# Altium schematic sheet Controller.SchDoc(Controller)
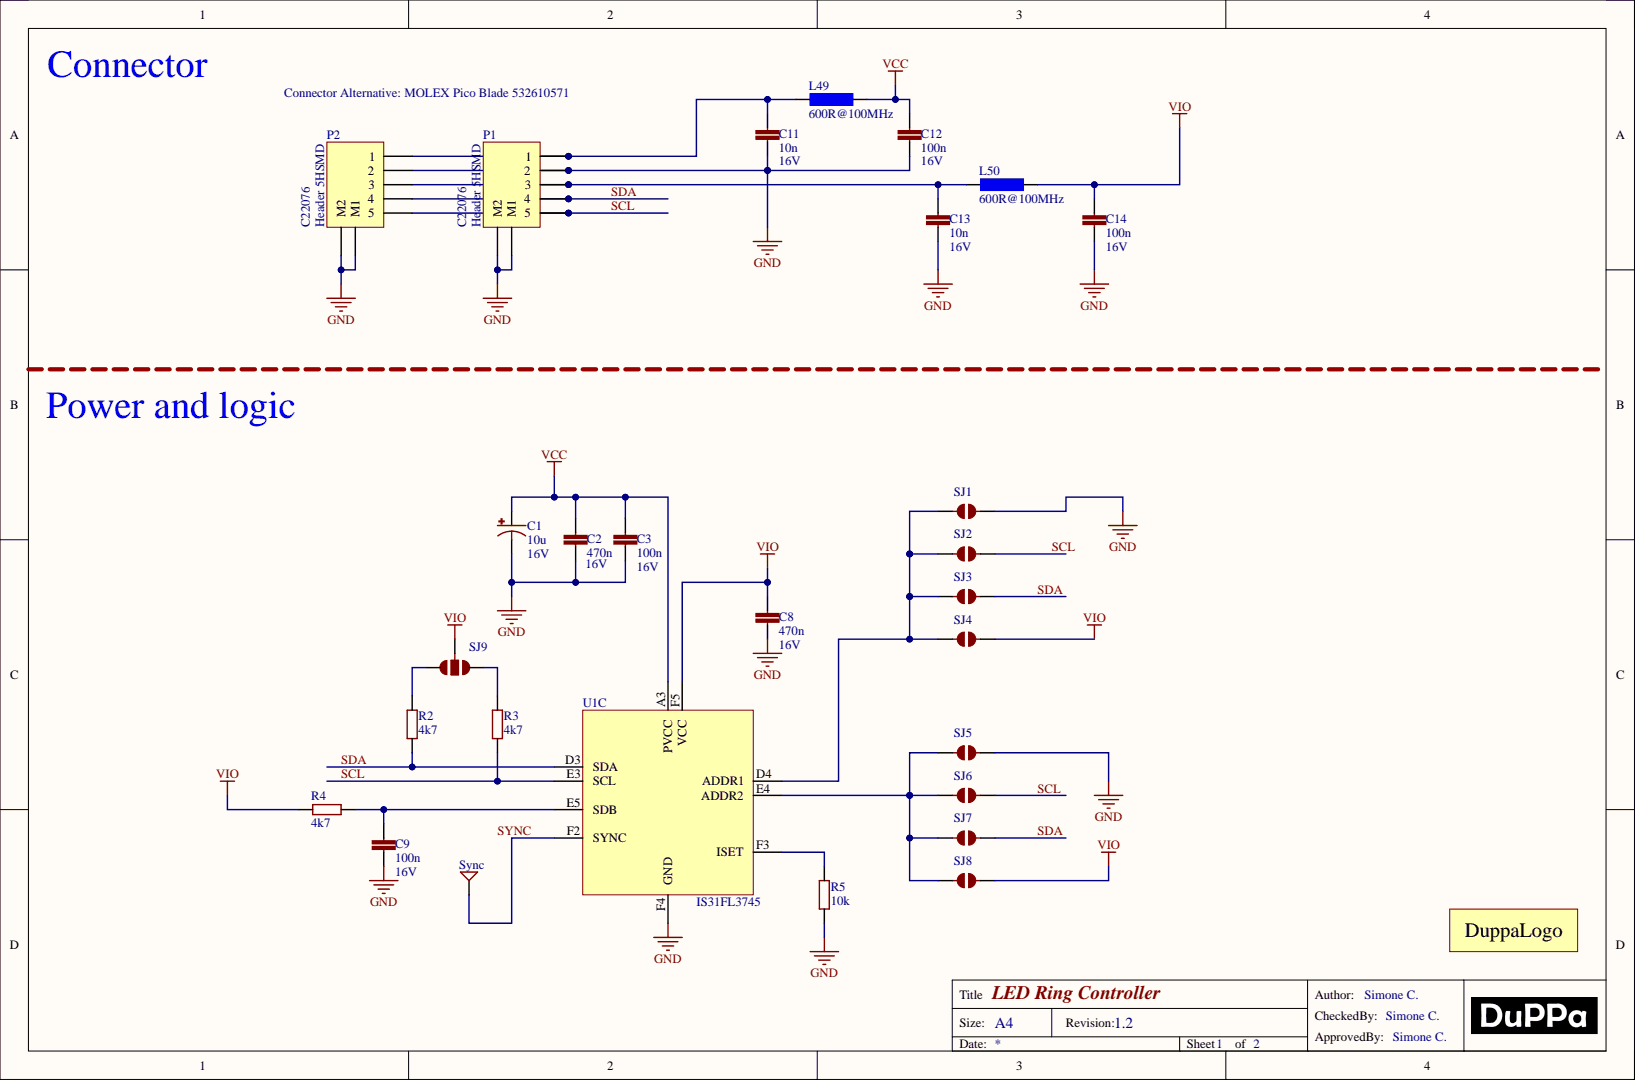
[diagram p0: Controller.SchDoc]

## Parts
C1  value 10u  Voltage: 16V  [SMC_A]  (hidden comment: Tantalum Case-A)
C2  value 470n  Voltage: 16V  [C0402]  (hidden comment: C-EUC0402)
C3  value 100n  Voltage: 16V  [C0402]  (hidden comment: C-EUC0402)
C8  value 470n  Voltage: 16V  [C0402]  (hidden comment: C-EUC0402)
C9  value 100n  Voltage: 16V  [C0402]  (hidden comment: C-EUC0402)
C11  value 10n  Voltage: 16V  [C0402]  (hidden comment: C-EUC0402)
C12  value 100n  Voltage: 16V  [C0402]  (hidden comment: C-EUC0402)
C13  value 10n  Voltage: 16V  [C0402]  (hidden comment: C-EUC0402)
C14  value 100n  Voltage: 16V  [C0402]  (hidden comment: C-EUC0402)
L49  600R@100MHz  [L0805]
L50  600R@100MHz  [L0402]
logo1  DuppaLogo  [DUPPA_TOP_300X76]  (no BOM)
P1  Header 5HSMD  PartNumber: C22076  [MOLX-52361-0571-5_V]
P2  Header 5HSMD  PartNumber: C22076  [MOLX-52361-0571-5_V]
R2  value 4k7  [R0402]  (hidden comment: R-EU_R0402)
R3  value 4k7  [R0402]  (hidden comment: R-EU_R0402)
R4  value 4k7  [R0402]  (hidden comment: R-EU_R0402)
R5  value 10k  [R0402]  (hidden comment: R-EU_R0402)
SJ1  SJ  [SJ]  (no BOM)
SJ2  SJ  [SJ]  (no BOM)
SJ3  SJ  [SJ]  (no BOM)
SJ4  SJ  [SJ]  (no BOM)
SJ5  SJ  [SJ]  (no BOM)
SJ6  SJ  [SJ]  (no BOM)
SJ7  SJ  [SJ]  (no BOM)
SJ8  SJ  [SJ]  (no BOM)
SJ9  SJ2W  [SJ_2]  (value not drawn)  (no BOM)
Sync  TestPoint Trough Hole  [PAD_1mm]  (value not drawn)
U1  IS31FL3745  [BGA36C50P6X6_290X290X40]  (part C)

## Nets
GND: C1.- C2.2 C3.2 C8.2 C9.2 C11.2 C12.2 C13.2 C14.2 P1.2 P1.M1 P1.M2 P2.2 P2.M1 P2.M2 R5.1 SJ1.2 SJ5.2 U1.F4(GND)
VCC: C1.+ C2.1 C3.1 C12.1 L49.2 U1.A3(PVCC)
VIO: C8.1 C14.1 L50.2 R4.2 SJ4.2 SJ8.2 SJ9.2 U1.F5(VCC)
SCL: P1.5 P2.5 R3.1 SJ2.2 SJ6.2 U1.E3(SCL)
SDA: P1.4 P2.4 R2.1 SJ3.2 SJ7.2 U1.D3(SDA)
NetC9_1: C9.1 R4.1 U1.E5(SDB)
NetC11_1: C11.1 L49.1 P1.1 P2.1
NetC13_1: C13.1 L50.1 P1.3 P2.3
NetR2_2: R2.2 SJ9.3
NetR3_2: R3.2 SJ9.1
NetR5_2: R5.2 U1.F3(ISET)
NetSJ1_1: SJ1.1 SJ2.1 SJ3.1 SJ4.1 U1.D4(ADDR1)
NetSJ5_1: SJ5.1 SJ6.1 SJ7.1 SJ8.1 U1.E4(ADDR2)
NetSync_0: Sync.0 U1.F2(SYNC)
SYNC:

## Library data of the parts
C1 = C-EUTANT_CASE_A: PartNumber: CA45-A016K106T; Component Kind: Standard; Library Name: rcl.IntLib
C2 C8 = C-EUC0402: PartNumber: CL05A474KA5NNNC; Component Kind: Standard; Library Name: rcl.IntLib
C3 C9 C12 C14 = C-EUC0402: PartNumber: CL05B104KO5NNNC; Component Kind: Standard; Library Name: rcl.IntLib
C11 C13 = C-EUC0402: PartNumber: CL05B103KA5NNNC; Component Kind: Standard; Library Name: rcl.IntLib
L49 = FERRITE: Ferrite Bead; PartNumber: CBW201209U601T; Component Kind: Standard; Library Name: Miscellaneous Devices.IntLib
L50 = FERRITE: Ferrite Bead; PartNumber: CBG100505U601T; Component Kind: Standard; Library Name: Miscellaneous Devices.IntLib
P1 P2 = Header 5HSMD: CONN HEADER SMD R/A 5POS 1.25MM; Manufacturer: BOOMELE(Boom Precision Elec); Component Kind: Standard; ComponentLink1Description: https://datasheet.ciiva.com/22699/1841145-22699961.pdf?src-supplier=Element14; ComponentLink1URL: https://datasheet.ciiva.com/22699/1841145-22699961.pdf?src-supplier=Element14; ComponentLink2Description: https://datasheet.ciiva.com/6083/1688141-6083001.pdf?src-supplier=Farnell; ComponentLink2URL: https://datasheet.ciiva.com/6083/1688141-6083001.pdf?src-supplier=Farnell; ComponentLink3Description: https://datasheet.ciiva.com/34303/1932563-34303344.pdf?src-supplier=Jameco; ComponentLink3URL: https://datasheet.ciiva.com/34303/1932563-34303344.pdf?src-supplier=Jameco; ComponentLink4Description: https://datasheet.ciiva.com/22680/1688141-22680432.pdf?src-supplier=Element14; ComponentLink4URL: https://datasheet.ciiva.com/22680/1688141-22680432.pdf?src-supplier=Element14; ComponentLink5Description: https://datasheet.ciiva.com/5150/0532610571-pcb-headers-170968-5150765.pdf?src-supplier=Mouser; ComponentLink5URL: https://datasheet.ciiva.com/5150/0532610571-pcb-headers-170968-5150765.pdf?src-supplier=Mouser; ComponentLink6Description: https://datasheet.ciiva.com/11747/0900766b8078fc8e-11747013.pdf?src-supplier=RSComponents; ComponentLink6URL: https://datasheet.ciiva.com/11747/0900766b8078fc8e-11747013.pdf?src-supplier=RSComponents; ComponentLink7Description: https://datasheet.ciiva.com/14488/1841145-14488445.pdf?src-supplier=Farnell; ComponentLink7URL: https://datasheet.ciiva.com/14488/1841145-14488445.pdf?src-supplier=Farnell; ComponentLink8Description: https://datasheet.ciiva.com/14156/gh116861-116874-e-14156229.pdf?src-supplier=Distrelec; ComponentLink8URL: https://datasheet.ciiva.com/14156/gh116861-116874-e-14156229.pdf?src-supplier=Distrelec; ComponentLink9Description: https://datasheet.ciiva.com/9468/0532610571-pcb-headers-9468503.pdf?src-supplier=Avnet; ComponentLink9URL: https://datasheet.ciiva.com/9468/0532610571-pcb-headers-9468503.pdf?src-supplier=Avnet; ComponentLink10Description: https://datasheet.ciiva.com/48768/0532610571-pcb-headers-48768266.pdf?src-supplier=Avnet; ComponentLink10URL: https://datasheet.ciiva.com/48768/0532610571-pcb-headers-48768266.pdf?src-supplier=Avnet; ComponentLink11Description: https://datasheet.ciiva.com/30252/225250532610571-pcb-headers-30252935.pdf?src-supplier=Onlinecomponents.com; ComponentLink11URL: https://datasheet.ciiva.com/30252/225250532610571-pcb-headers-30252935.pdf?src-supplier=Onlinecomponents.com; ComponentLink12Description: https://datasheet.ciiva.com/16658/0532610571-pcb-headers-16658426.pdf?src-supplier=Avnet+Europe; ComponentLink12URL: https://datasheet.ciiva.com/16658/0532610571-pcb-headers-16658426.pdf?src-supplier=Avnet+Europe; ComponentLink13Description: https://datasheet.ciiva.com/17086/70266923-17086171.pdf?src-supplier=Allied+Electronics; ComponentLink13URL: https://datasheet.ciiva.com/17086/70266923-17086171.pdf?src-supplier=Allied+Electronics; Library Name: Miscellaneous Connectors.IntLib
R2-R4 = R-EU_R0402: PartNumber: 0402WGJ0472TCE; Component Kind: Standard; Library Name: rcl.IntLib
R5 = R-EU_R0402: PartNumber: RC-02W1002FT; Component Kind: Standard; Library Name: rcl.IntLib
Sync = TestPoint-TH: PartNumber: RS-200-208; Component Kind: Standard; Library Name: Miscellaneous Devices.IntLib
U1 = IS31FL3745: 18×8 DOTS MATRIX LED DRIVER; Manufacturer: ISSI; Manufacturer Part Number: IS31FL3745-CLS4-TR; Category: LED Drivers; Component Kind: Standard; ComponentLink1Description: http://www.issi.com/WW/pdf/31FL3745.pdf?src-supplier=Digi-Key; ComponentLink1URL: http://www.issi.com/WW/pdf/31FL3745.pdf?src-supplier=Digi-Key; Library Name: ISSI.IntLib; Packaging: Tape and Reel; PartNumber: IS31FL3745; Pricing 1: 1=3,20000, 10=2,87600, 25=2,71880, 100=2,35640, 250=2,23552, 500=2,00594, 1000=1,71000 (USD); RoHS: No; RoHS Compliant: No; Stock 1: 2480; Supplier 1: Digi-Key; Supplier Part Number 1: 706-1673-1-ND
logo1 = DuppaLogo: Library Name: DuppaLogo.IntLib
SJ1-SJ8 = SJ: Library Name: jumper.IntLib
SJ9 = SJ2W: Library Name: jumper.IntLib
